# Altium schematic sheet Color 3_click_v100.SchDoc
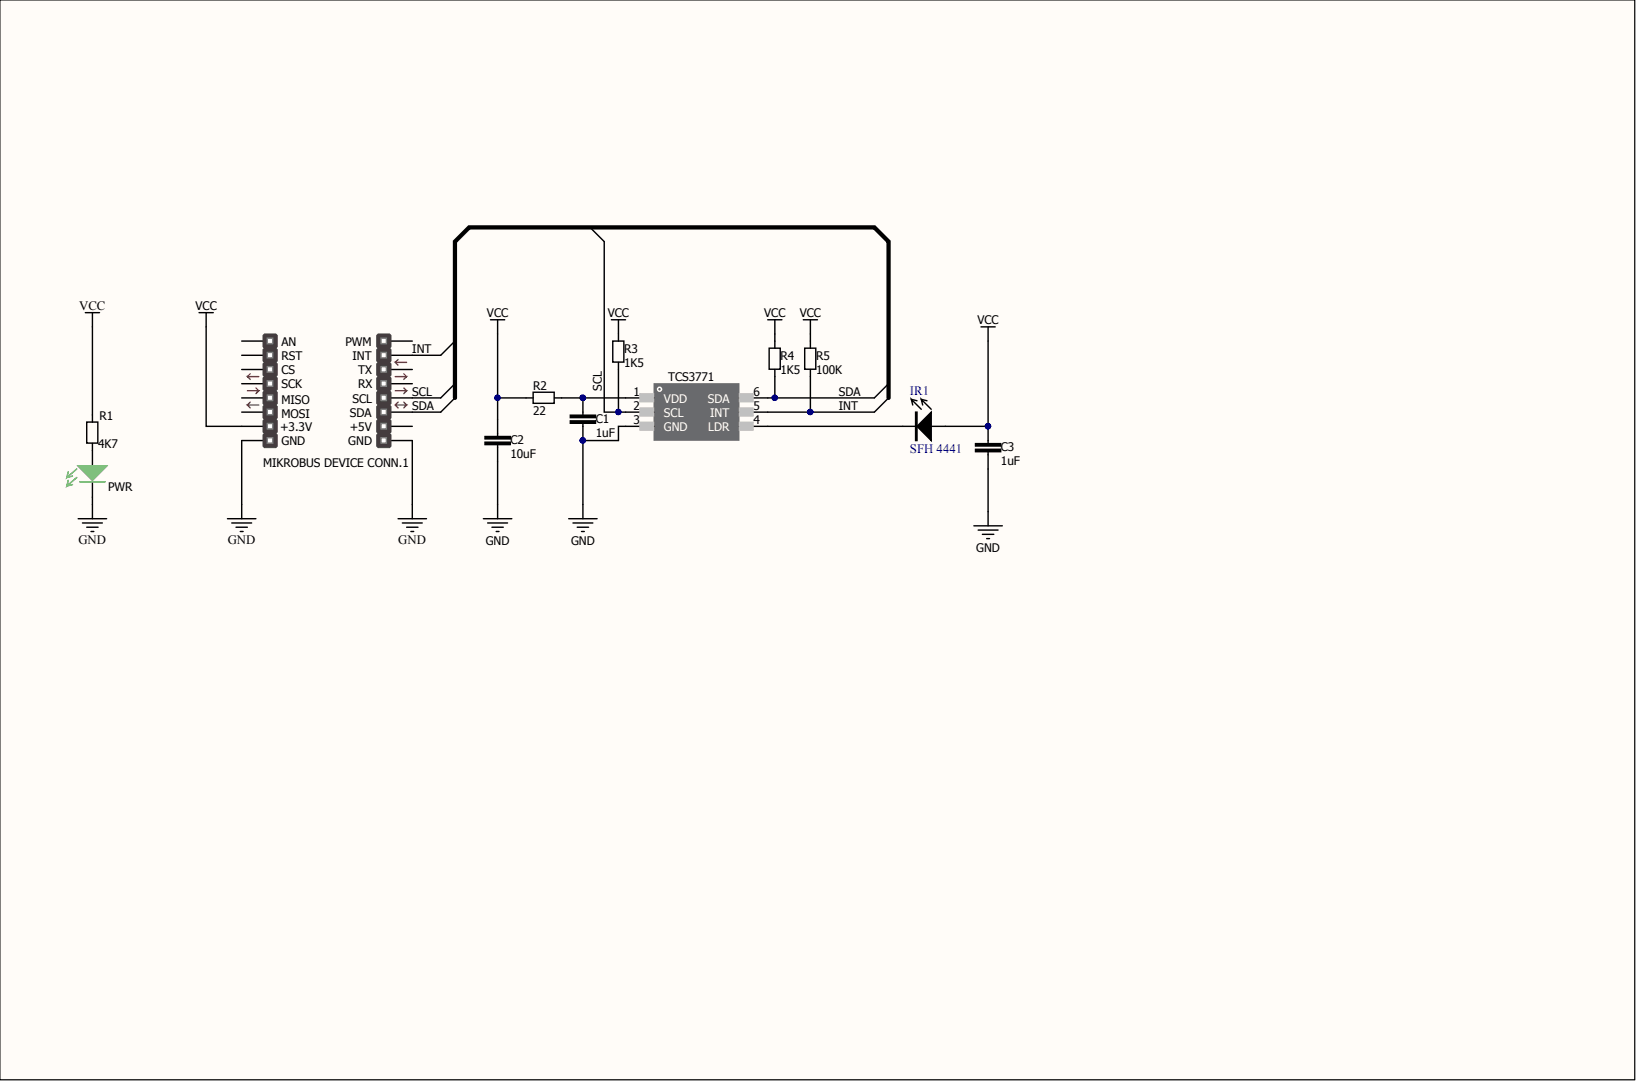
[diagram p0: Color 3_click_v100.SchDoc]

## Parts
C1  1uF  [0805_C_V3]
C2  10uF  [0805_C_V3]
C3  1uF  [0805_C_V3]
IR1  SFH 4441  [IR2319X90N-NoOverlay]
MIKROBUS_DEVICE_CONN.1  MIKROBUS DEVICE CONN.  [MIKRO_BUS_MINI]
PWR  LED  [0805 - LED]  (value not drawn)
R1  4K7  [0805_R_V3]
R2  22  [0805_R_V3]
R3  1K5  [0805_R_V3]
R4  1K5  [0805_R_V3]
R5  100K  [0805_R_V3]
TCS3771  (no value)  [TCS37717FN]

## Nets
GND: C1.2 C2.2 C3.2 MIKROBUS_DEVICE_CONN.1.8(GND) MIKROBUS_DEVICE_CONN.1.9(GND) PWR.2 TCS3771.3(GND)
VCC: C2.1 C3.1 IR1.2 MIKROBUS_DEVICE_CONN.1.7(+3.3V) R1.2 R2.2 R3.1 R4.1 R5.1
INT: MIKROBUS_DEVICE_CONN.1.15(INT) R5.2 TCS3771.5(INT)
SCL: MIKROBUS_DEVICE_CONN.1.12(SCL) R3.2 TCS3771.2(SCL)
SDA: MIKROBUS_DEVICE_CONN.1.11(SDA) R4.2 TCS3771.6(SDA)
NetC1_1: C1.1 R2.1 TCS3771.1(VDD)
NetIR1_1: IR1.1 TCS3771.4(LDR)
NetPWR_1: PWR.1 R1.1
N00011:

Not connected: MIKROBUS_DEVICE_CONN.1 1(AN) 2(RST) 3(CS) 4(SCK) 5(MISO) 6(MOSI) 10(+5V) 13(RX) 14(TX) 16(PWM)

## Library data of the parts
C1-C3 = CAP NP: Component Kind: Standard; SMD: Yes; Library Name: RTCSPI_click_v100.SchLib
IR1 = 2911010042349: EMITTER IR 950NM 100MA SMD; Manufacturer: OSRAM Opto Semiconductors Inc.; Database Table Name: optoelectronics; id: 16; item_id: 4914; Voltage Forward: 1.5V; Assembly: SMD; Barcode: 2911010042349; Category: Optoelectronics; Color: IR; Component Kind: Standard; Current  Max: 100mA; Datasheet URL: http://www.osram-os.com/Graphics/XPic0/00084576_0.pdf; Manufacturer Part Number: SFH 4441; Package Case: 2-SMD, No Lead; Part Type: Optoelectronics; Wavelength: 950nm; Library Name: erp-baza.SVNDbLib
MIKROBUS_DEVICE_CONN.1 = MIKROBUS DEVICE CONN.: mikroBUS Target Header; Component Kind: Standard; Library Name: SCH_Rega.SchLib
R1-R5 = RES: Resistor; Component Kind: Standard; SMD: Yes; Library Name: template_click_v100.SCHLIB
TCS3771 = TCS3771: Component Kind: Standard
PWR = LED: Component Kind: Standard; SMD: Yes; Library Name: template_click_v100.SCHLIB
